# Altium schematic sheet 08_Module_0.SchDoc
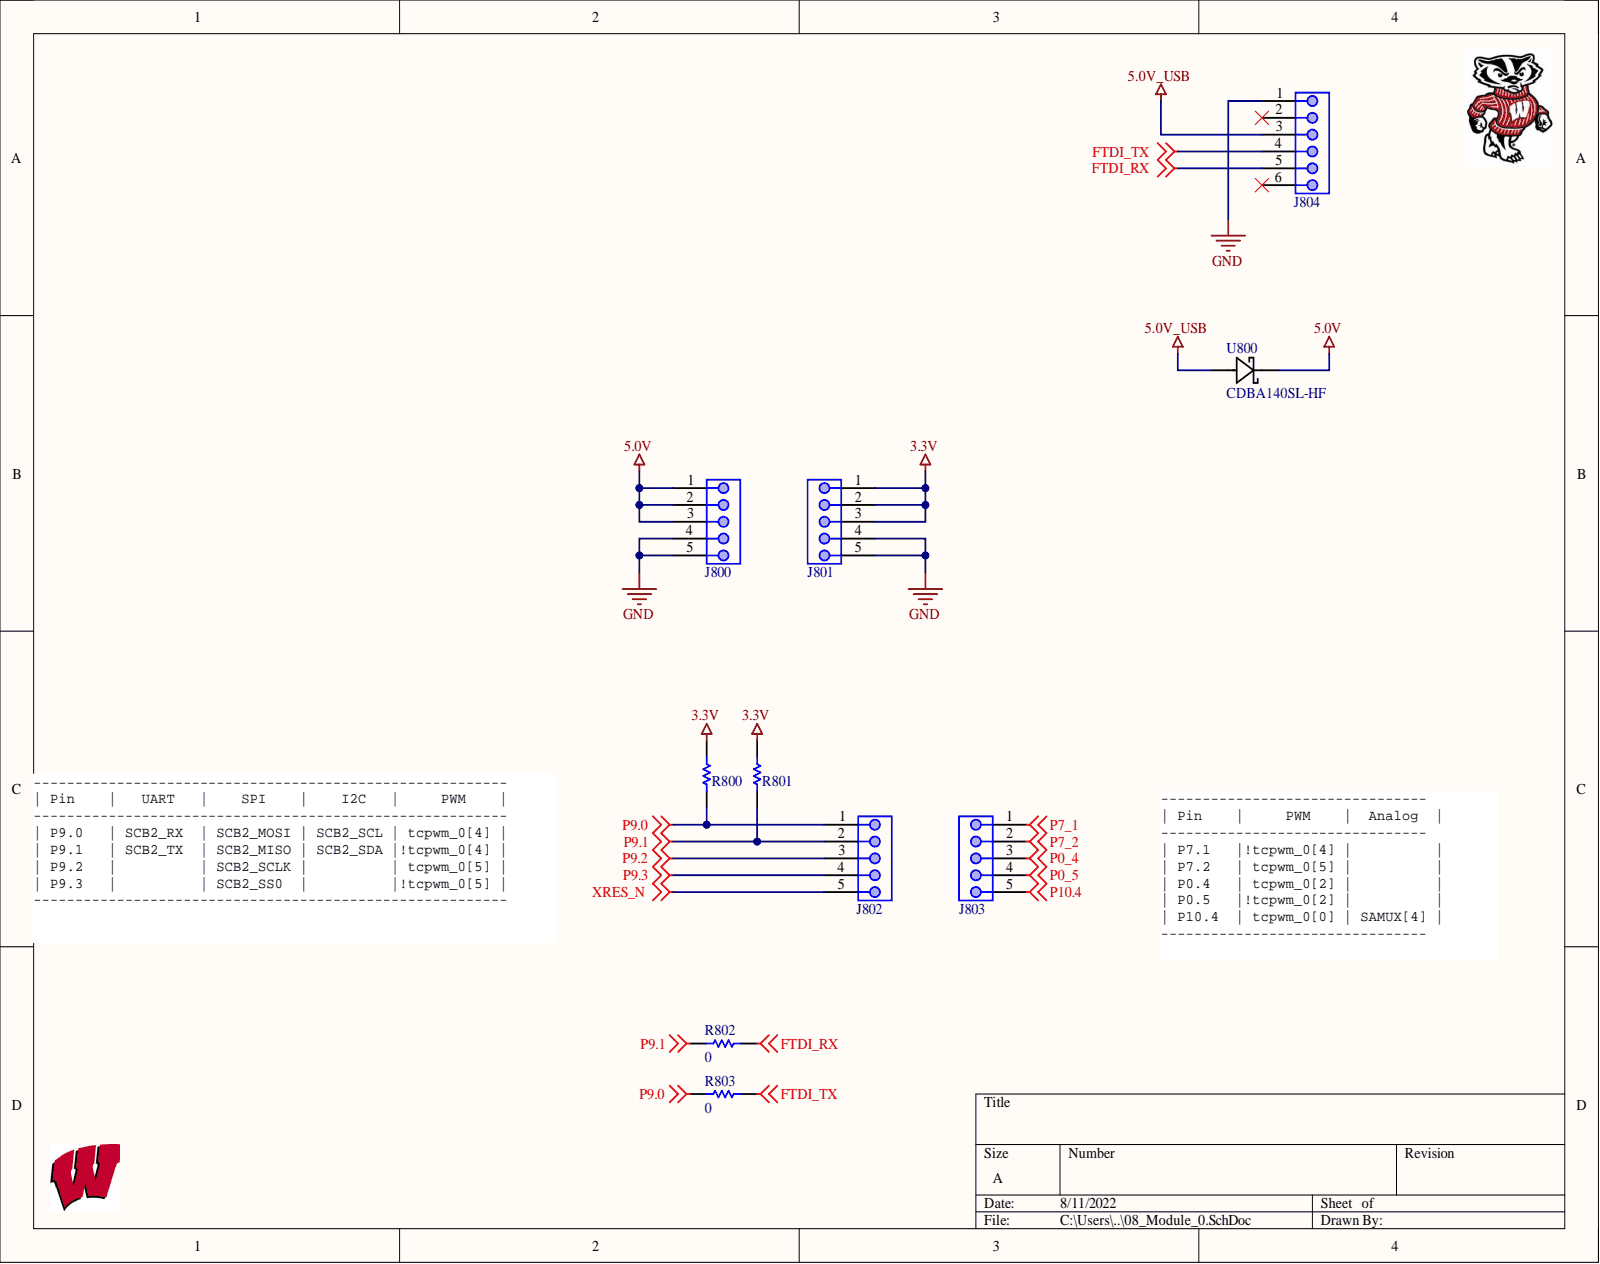
[diagram p0: 08_Module_0.SchDoc]

## Parts
J800  CONN_HEADER_MALE_1x5  [HDR1X5]  (value not drawn)
J801  CONN_HEADER_MALE_1x5  [HDR1X5]  (value not drawn)
J802  CONN_HEADER_MALE_1x5  [HDR1X5]  (value not drawn)
J803  CONN_HEADER_MALE_1x5  [HDR1X5]  (value not drawn)
J804  CONN_HEADER_MALE_1x6  [HDR1X6]  (value not drawn)
R800  RES_0805_K_010.00  [RES_0805]  (value not drawn)
R801  RES_0805_K_010.00  [RES_0805]  (value not drawn)
R802  0  [RES_0805]
R803  0  [RES_0805]
U800  CDBA140SL-HF  [CDBA-HF]

## Nets
3.3V: J801.1 J801.2 J801.3 R800.2 R801.2
5.0V: J800.1 J800.2 J800.3 U800.1
5.0V_USB: J804.3 U800.2
FTDI_RX: J804.5 R802.1
FTDI_TX: J804.4 R803.1
GND: J800.4 J800.5 J801.4 J801.5 J804.1
P0_4: J803.3
P0_5: J803.4
P7_1: J803.1
P7_2: J803.2
P9.0: J802.1 R800.1 R803.2
P9.1: J802.2 R801.1 R802.2
P9.2: J802.3
P9.3: J802.4
P10.4: J803.5
XRES_N: J802.5

Not connected: J804 2 6

## Library data of the parts
J800-J803 = CONN_HEADER_MALE_1x5: Header, 5-Pin; Manufacturer 1: Sullins; Manufacturer Part Number 1: PREC005SACN-RC; Connector Type: Male Pin; Number of Positions: 5; Pitch: 0.1inch; Color: Black; Component Kind: Standard; ComponentLink1Description: http://www.farnell.com/cad/1737268.pdf; ComponentLink1URL: http://www.farnell.com/cad/1737268.pdf; ComponentLink2Description: http://katalog.we-online.de/em/datasheet/6130xx11121.pdf; ComponentLink2URL: http://katalog.we-online.de/em/datasheet/6130xx11121.pdf; ComponentLink3Description: https://datasheet.ciiva.com/741/xrxczzzsxxn-rc-st-11635-b-741840.pdf?src-supplier=Digi-Key; ComponentLink3URL: https://datasheet.ciiva.com/741/xrxczzzsxxn-rc-st-11635-b-741840.pdf?src-supplier=Digi-Key; Contact Mating Length: 0.23inch; Contact Plating: Tin; Library Name: Connectors.SchLib; Mount: Through Hole; Number of Rows: 1; Package Quantity: 1; Packaging: Bulk; Pricing 1: 1=0.19000, 10=0.17400, 25=0.12960, 50=0.11120, 100=0.10740, 250=0.09264, 500=0.08892 (USD); RoHS: Yes; Stock 1: 0; Supplier 1: Digi-Key; Supplier Part Number 1: S1032EC-05-ND; Termination: Solder
J804 = CONN_HEADER_MALE_1x6: Conn Header Vert 6POS 2.54MM; Manufacturer 1: Sullins; Manufacturer Part Number 1: PEC06SFAN; Connector Type: Male Pin; Gender: Male; Number of Contacts: 6; Pitch: 0.1inch; Category: Connectors; Color: Black; Component Kind: Standard; ComponentLink1Description: http://www.farnell.com/cad/1737268.pdf; ComponentLink1URL: http://www.farnell.com/cad/1737268.pdf; ComponentLink2Description: http://katalog.we-online.de/em/datasheet/6130xx11121.pdf; ComponentLink2URL: http://katalog.we-online.de/em/datasheet/6130xx11121.pdf; ComponentLink3Description: https://datasheet.ciiva.com/741/xrxczzzsxxn-rc-st-11635-b-741840.pdf?src-supplier=Digi-Key; ComponentLink3URL: https://datasheet.ciiva.com/741/xrxczzzsxxn-rc-st-11635-b-741840.pdf?src-supplier=Digi-Key; ComponentLink4Description: https://s3.amazonaws.com/catalogspreads-pdf/PAGE108-109%20.100%20MALE%20HDR.pdf?src-supplier=Digi-Key; ComponentLink4URL: https://s3.amazonaws.com/catalogspreads-pdf/PAGE108-109%20.100%20MALE%20HDR.pdf?src-supplier=Digi-Key; Contact Material: Bronze; Contact Mating Length: 0.318inch; Contact Plating: Tin; Contact Type: Male Pin; Height: 2.54mm; Housing Color: Black; Housing Material: Plastic; Lead Free: Lead Free; Length: 15.2mm; Library Name: Connectors.SchLib; Mount: Through Hole; Number of Positions: 6; Number of Rows: 1; Package Quantity: 1; Packaging: Bulk; Pricing 1: 1=0.44000, 10=0.40800, 25=0.36720, 50=0.32640, 100=0.31280, 250=0.28560, 500=0.27200 (USD); RoHS: Yes; RoHS Compliant: Yes; Stock 1: 11920; Supplier 1: Digi-Key; Supplier Part Number 1: S1212E-06-ND; Termination: Solder; Width: 2.54mm
R800 R801 = RES_0805_K_010.00: RES SMD 10K OHM 1% 1/8W 0805; Manufacturer 1: Yageo; Manufacturer Part Number 1: RC0805FR-0710KL; Max Power Dissipation: 125mW; Resistance: 10kR; Temperature Coefficient: 100ppm/°C; Voltage Rating: 150V; Case Code (Imperial): 0805; Case Code (Metric): 2012; Case/Package: 0805; Category: Chip SMD Resistors; Component Kind: Standard; ComponentLink1Description: https://datasheet.ciiva.com/11808/0900766b8106beab-11808151.pdf?src-supplier=RSComponents; ComponentLink1URL: https://datasheet.ciiva.com/11808/0900766b8106beab-11808151.pdf?src-supplier=RSComponents; ComponentLink2Description: https://datasheet.ciiva.com/12669/rc0805-12669688.pdf?src-supplier=Mouser; ComponentLink2URL: https://datasheet.ciiva.com/12669/rc0805-12669688.pdf?src-supplier=Mouser; ComponentLink3Description: https://datasheet.ciiva.com/46447/444164-46447563.pdf?src-supplier=Element14; ComponentLink3URL: https://datasheet.ciiva.com/46447/444164-46447563.pdf?src-supplier=Element14; ComponentLink4Description: https://datasheet.ciiva.com/2456/pyu-r-int-thick-7-2456972.pdf?src-supplier=Digi-Key; ComponentLink4URL: https://datasheet.ciiva.com/2456/pyu-r-int-thick-7-2456972.pdf?src-supplier=Digi-Key; ComponentLink5Description: https://datasheet.ciiva.com/2456/pyu-r-int-thick-7-2456973.pdf?src-supplier=Digi-Key; ComponentLink5URL: https://datasheet.ciiva.com/2456/pyu-r-int-thick-7-2456973.pdf?src-supplier=Digi-Key; ComponentLink6Description: https://datasheet.ciiva.com/2456/pyu-r-int-thick-7-2456974.pdf?src-supplier=Digi-Key; ComponentLink6URL: https://datasheet.ciiva.com/2456/pyu-r-int-thick-7-2456974.pdf?src-supplier=Digi-Key; ComponentLink7Description: https://datasheet.ciiva.com/16747/rc0805-16747087.pdf?src-supplier=Future+Electronics; ComponentLink7URL: https://datasheet.ciiva.com/16747/rc0805-16747087.pdf?src-supplier=Future+Electronics; Construction: Rectangular; Depth: 1.25mm; Features: Moisture Resistant; Height: 0.024inch; Lead Free: Lead Free; Length: 2mm; Library Name: Resistors.SchLib; Max Operating Temperature: 155°C; Military Standard: Not; Min Operating Temperature: -55°C; Number of Terminations: 2; Package Quantity: 1; Packaging: Tape and Reel; Power Rating: 125mW; Pricing 1: 1=0.10000, 10=0.04200, 100=0.01690, 1000=0.00759, 2500=0.00659 (USD); REACH SVHC: Unknown; Resistance Tolerance: 1%; Resistor Type: Thick Film; RoHS: Yes; RoHS Compliant: Yes; Series: RC; Size Code: 0805; Stock 1: 7562122; Supplier 1: Digi-Key; Supplier Part Number 1: 311-10.0KCRCT-ND; Technology: THICK FILM; Termination: SMD/SMT; Tolerance: 1%; Width: 0.049inch
R802 R803 = RES_0805_000.00: RES 0, , 1%, 0.125W, 1/8W 0805; Manufacturer: Panasonic Electronic Components; Manufacturer Part Number: ERJ-6GEY0R00V; Tolerance: Jumper; Category: Resistors; Component Kind: Standard; Composition: Thick Film; Features: Automotive AEC-Q200; Height: 0.028" (0.70mm); Library Name: Resistors.SchLib; Number of Terminations: 2; Operating Temperature: -55°C ~ 155°C; Package / Case: 0805 (2012 Metric); Packaging: Cut Tape (CT); Power (Watts): 0.125W, 1/8W; Resistance: 0; RoHS: RoHS Compliant; Size / Dimension: 0.079" L x 0.049" W (2.00mm x 1.25mm); Supplier: Digi-Key; Supplier 1: Digi-Key; Supplier Device Package: 0805; Supplier Part Number: P0.0ACT-ND; Supplier Part Number 1: P0.0ACT-ND; Temperature Coefficient: -; Value: 0
U800 = CDBA140SL-HF: Rectifier Diode Schottky 40V 1A 2-Pin SMA T/R; Manufacturer 1: Comchip; Average Rectified Current: 1.4A; Max Surge Current: 30A; Case/Package: DO-214AC; Category: Rectifier Diodes; Component Kind: Standard; ComponentLink1Description: https://datasheet.ciiva.com/6377/cdba120sl-hfthru295959-cdba140sl-hfreva-6377798.pdf?src-supplier=Digi-Key; ComponentLink1URL: https://datasheet.ciiva.com/6377/cdba120sl-hfthru295959-cdba140sl-hfreva-6377798.pdf?src-supplier=Digi-Key; Digi-Key_Part_Number_1: 641-1762-1-ND; Digi-Key_Part_Number_2: 641-1762-2-ND; Digi-Key_Part_Number_3: 641-1762-2-NDL; Digi-Key_Part_Number_4: 641-1762-6-ND; Digi-Key_Part_Number_5: CDBA140SL-HF-ND; Library Name: Diodes.SchLib; Manufacturer Part Number 1: CDBA140SL-HF; Manufacturer_Part_Number: CDBA140SL-HF; Max Reverse Voltage (DC): 40V; Mfr_Name: Comchip; Mount: Surface Mount; Package Quantity: 5000; Packaging: Tape and Reel; Pricing 1: 1=0.60000, 10=0.52400, 100=0.40140, 500=0.31734, 1000=0.25387, 2000=0.23007 (USD); RefDes: RefDes; RoHS: Yes; RoHS Compliant: Yes; Series: -; Stock 1: 277936; Supplier 1: Digi-Key; Supplier Part Number 1: 641-1762-1-ND; Type: DEV; Copyright: Copyright (C) 2022 Ultra Librarian. All rights reserved.
